# Altium schematic sheet Power.SchDoc
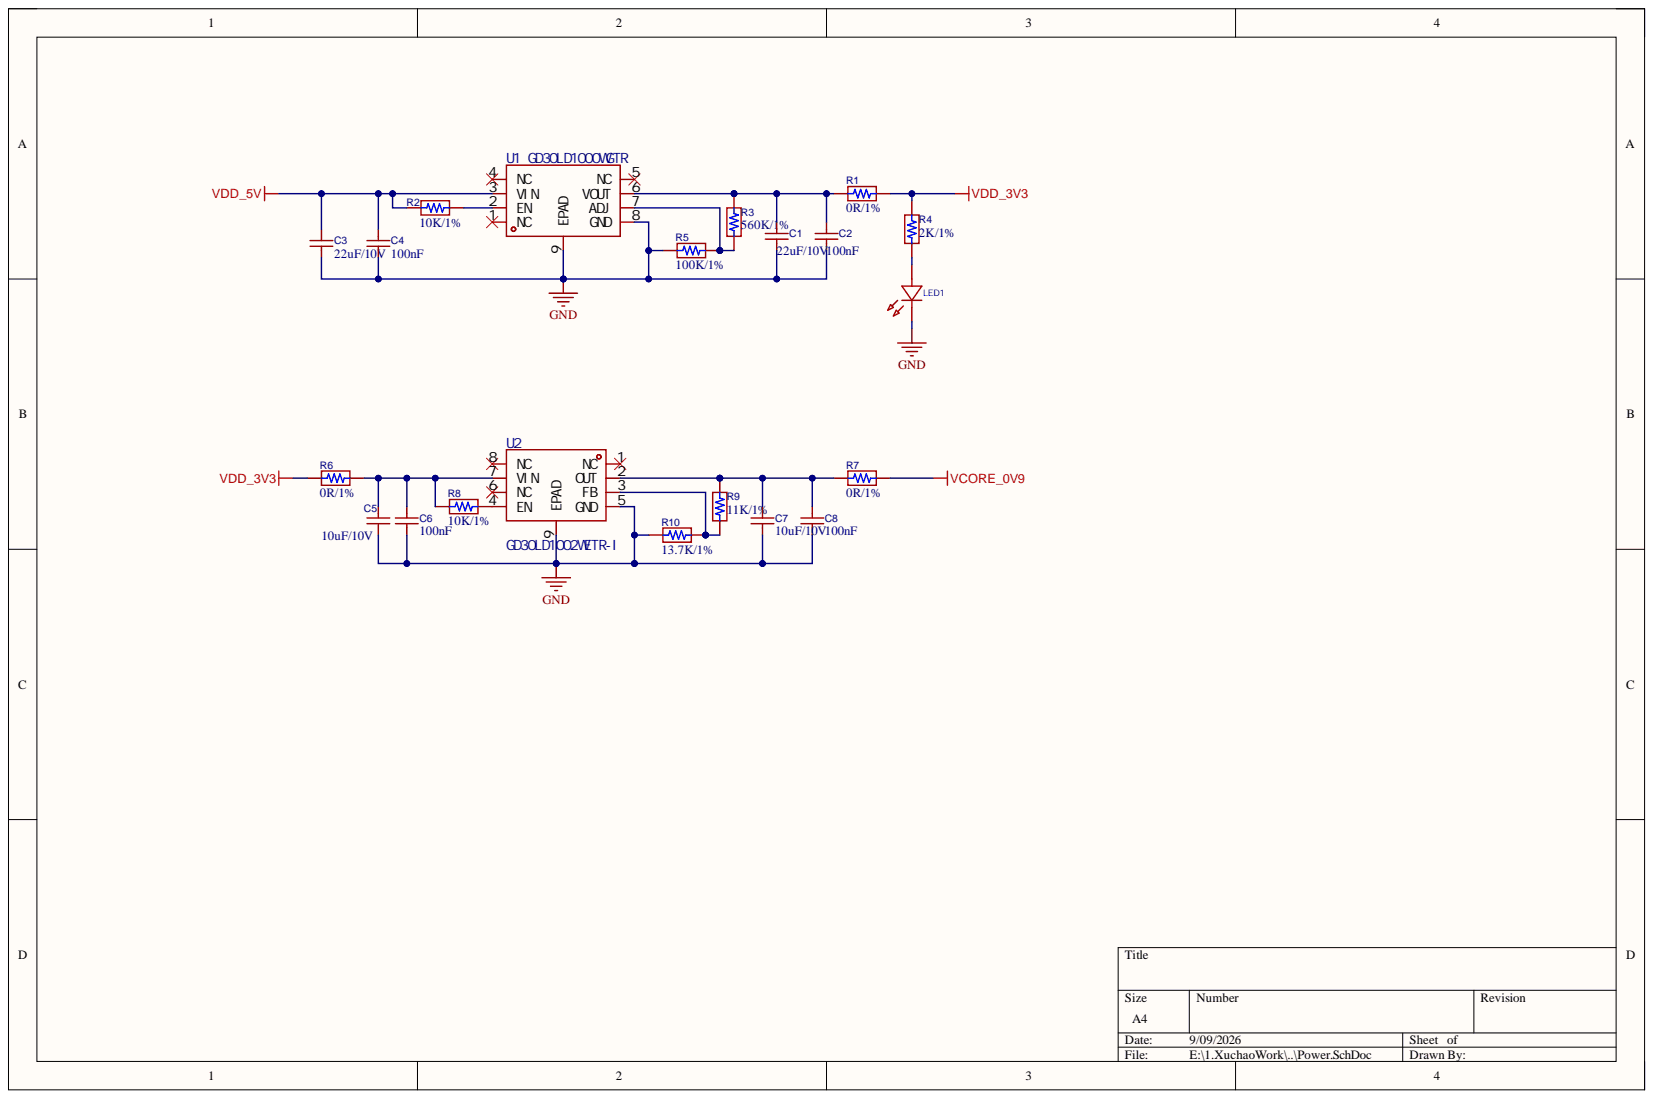
[diagram p0: Power.SchDoc]

## Parts
C1  22uF/10V  [C0603]
C2  100nF  [C0402]
C3  22uF/10V  [C0603]
C4  100nF  [C0603]
C5  10uF/10V  [C0603]
C6  100nF  [C0402]
C7  10uF/10V  [C0402]
C8  100nF  [C0402]
LED1  LED  [LED 0603B]
R1  0R/1%  [R0603]
R2  10K/1%  [R0603]
R3  560K/1%  [R0603]
R4  2K/1%  [R0603]
R5  100K/1%  [R0603]
R6  0R/1%  [R0603]
R7  0R/1%  [R0603]
R8  10K/1%  [R0402]
R9  11K/1%  [R0603]
R10  13.7K/1%  [R0603]
U1  GD30LD1000WGTR  [SOP-8_L4.9-W3.9-P1.27-LS6.0-BL-EP]
U2  GD30LD1002WETR-I  [DFN-8_L3.0-W3.0-P0.65-BL-EP]

## Nets
GND: C1.1 C2.1 C3.1 C4.1 C5.1 C6.1 C7.1 C8.1 LED1.2 R5.1 R10.1 U1.8(GND) U1.9(EPAD) U2.5(GND) U2.9(EPAD)
VCORE_0V9: R7.1
VDD_3V3: R1.1 R4.1 R6.2
VDD_5V: C3.2 C4.2 R2.1 U1.3(VIN)
NetC1_2: C1.2 C2.2 R1.2 R3.2 U1.6(VOUT)
NetC5_2: C5.2 C6.2 R6.1 R8.2 U2.7(VIN)
NetC7_2: C7.2 C8.2 R7.2 R9.2 U2.2(OUT)
NetLED1_1: LED1.1 R4.2
NetR2_2: R2.2 U1.2(EN)
NetR3_1: R3.1 R5.2 U1.7(ADJ)
NetR8_1: R8.1 U2.4(EN)
NetR9_1: R9.1 R10.2 U2.3(FB)
NetU1_1: U1.1(NC)
NetU1_4: U1.4(NC)
NetU1_5: U1.5(NC)
NetU2_1: U2.1(NC)
NetU2_6: U2.6(NC)
NetU2_8: U2.8(NC)

## Library data of the parts
C1-C8 = 0SMD_CAP: Symbol: CL10A106KP8NNNC; Component Kind: Standard; Library Name: CYKJ_LibPkg.IntLib
LED1 = 0SMD_LED: Manufacturer: XINGLIGHT(成兴光); Add into BOM: yes; Device: XL-1608UBC-04; Forward Current: 20mA; Illumination Color: 蓝色; Lens Color: 无色透明透镜; Luminous Intensity: 300mcd; Peak Wavelength: 470nm; Power(Watts): 70mW; Viewing Angle: 120°; Voltage - Forward(Vf): 3.3V; Wavelength - Dominant: 455nm~475nm; Color Temperature: -; Component Kind: Standard; Convert to PCB: yes; Datasheet: https://item.szlcsc.com/datasheet/XL-1608UBC-04/1051416.html; Diode Configuration: -; JLCPCB Part Class: Extended Part; Lamp Holder Type: -; LCSC Part Name: 0603蓝色贴片式发光二极管; Manufacturer Part: XL-1608UBC-04; Mounting Style: 正贴; Operating Temperature: -; Supplier: LCSC; Supplier Footprint: 0603; Supplier Part: C965807; Symbol: XL-1608UBC-04; Library Name: CYKJ_library.SchLib
R1-R10 = Resisteors: RES_SMD; Component Kind: Standard; Library Name: CYKJ_library.SchLib
U1 = LDO_GD30LD1000WGTR: Manufacturer: 兆易创新; Add into BOM: yes; Component Kind: Standard; Convert to PCB: yes; Datasheet: https://www.gigadevice.com.cn/Public/Uploads/uploadfile/files/20230616/GD30LD1000x_Datasheet_Rev1.0-814.pdf; LCSC Part Name: GD30LD1000WGTR; Manufacturer Part: GD30LD1000WGTR; Output Type: -; Supplier Footprint: SOP-8-EP-150mil; Symbol: GD30LD1000WGTR; Library Name: CYKJ_LibPkg.IntLib
U2 = LDO_GD30LD1002WETR-I: Manufacturer: 兆易创新; Add into BOM: yes; Component Kind: Standard; Convert to PCB: yes; Datasheet: https://www.gigadevice.com.cn/Public/Uploads/uploadfile/files/20230616/GD30LD1002x_Datasheet_Rev1.0.pdf; Supplier Footprint: DFN-8-EP(3x3); Symbol: GD30LD1002WETR-I; Library Name: CYKJ_LibPkg.IntLib
